# Altium schematic sheet Mach IOT wemos D1.SchDoc
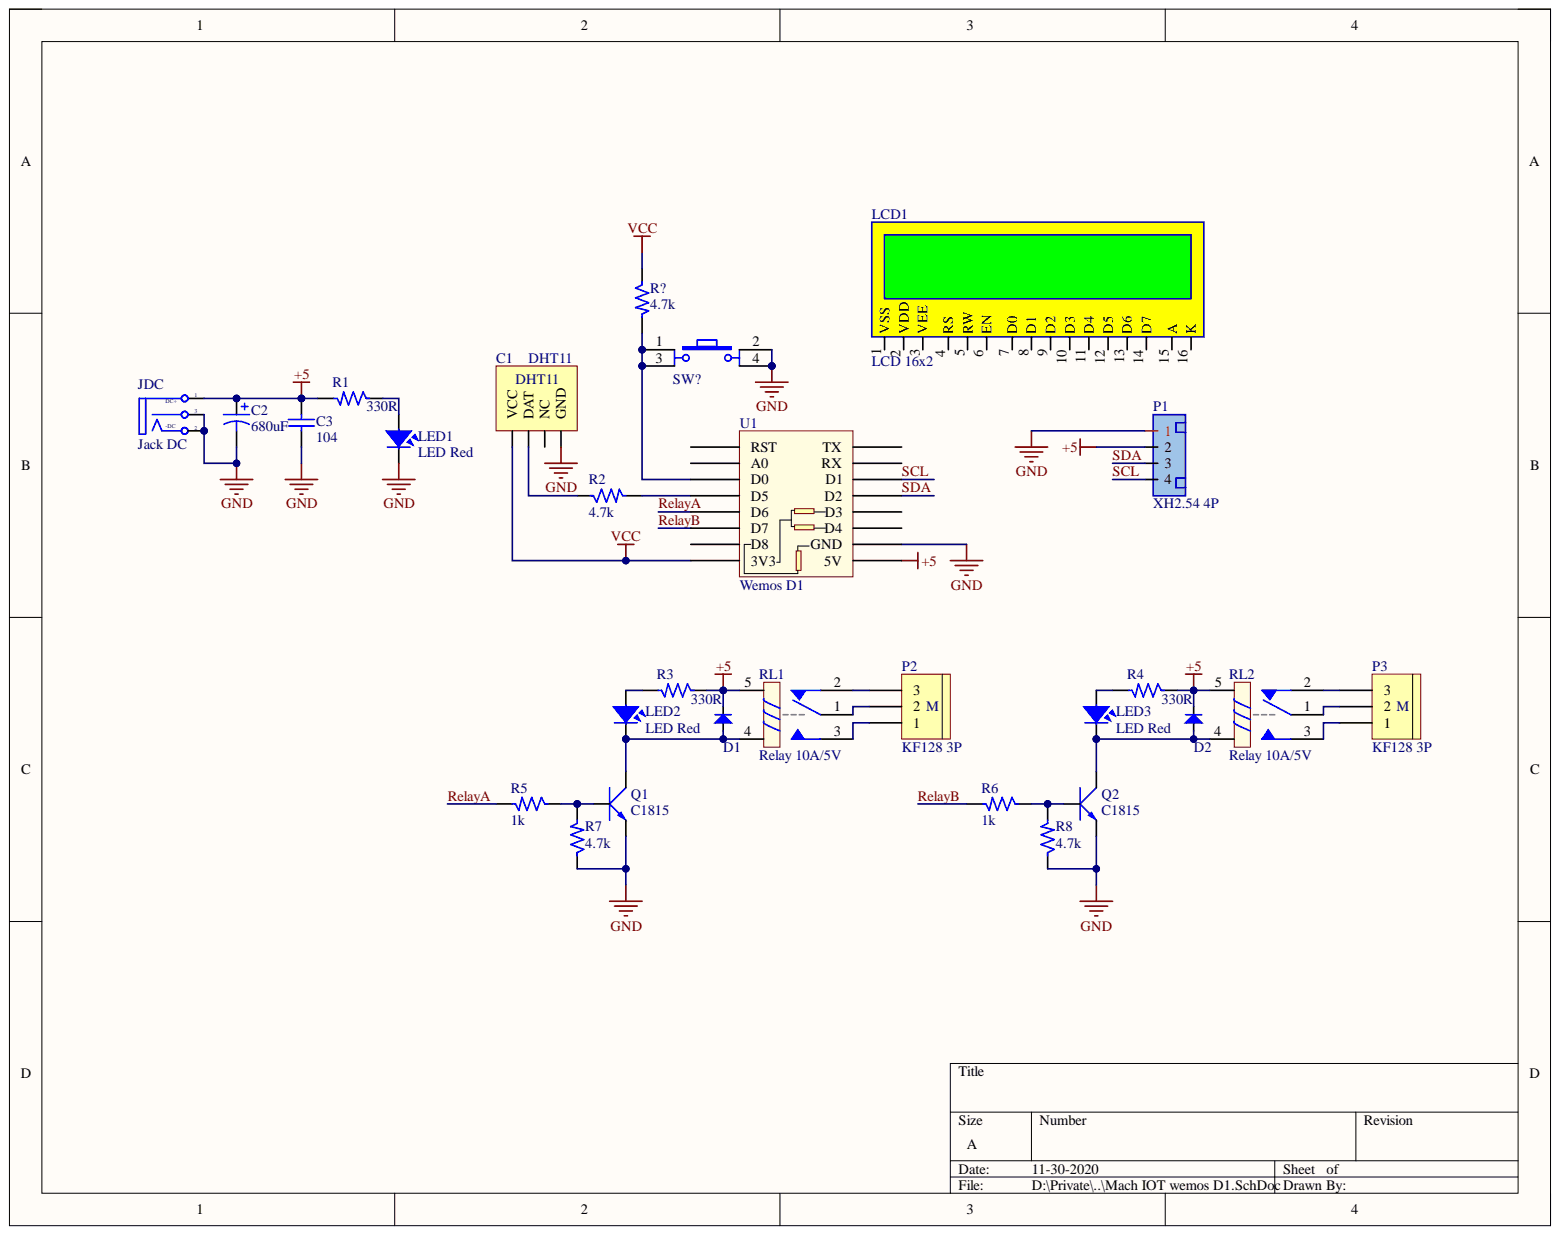
[diagram p0: Mach IOT wemos D1.SchDoc]

## Parts
C1  DHT11  [DHT11]
C2  680uF  [C10mm]
C3  104  [CAP_CERAMIC d2.54]
D1  1n4007  [DIODE/POW/1A]  (value not drawn)
D2  1n4007  [DIODE/POW/1A]  (value not drawn)
JDC  Jack DC  [JACK_DC 5.5 mm]
LCD1  LCD 16x2  [LCD_16x2]
LED1  LED Red  [LED_RED_5MM]
LED2  LED Red  [LED_RED_5MM]
LED3  LED Red  [LED_RED_5MM]
P1  XH2.54 4P  [HEADER 4P XH2.54]
P2  KF128 3P  [KF128 5.08 3P]
P3  KF128 3P  [KF128 5.08 3P]
Q1  C1815  [QTO-92]
Q2  C1815  [QTO-92]
R1  330R  [RES_1/4 W]
R2  4.7k  [RES_1/4 W]
R3  330R  [RES_1/4 W]
R4  330R  [RES_1/4 W]
R5  1k  [RES_1/4 W]
R6  1k  [RES_1/4 W]
R7  4.7k  [RES_1/4 W]
R8  4.7k  [RES_1/4 W]
R?  4.7k  [RES_1/4 W]
RL1  Relay 10A/5V  [Relay 5 pin 10A]
RL2  Relay 10A/5V  [Relay 5 pin 10A]
SW?  BT4  [SW4]  (value not drawn)
U1  Wemos D1  [Wemos D1 mini HEADER]

## Nets
+5: C2.1 C3.2 D1.2 D2.2 JDC.1 P1.2 R1.2 R3.1 R4.1 RL1.5 RL2.5 U1.5V
GND: C1.4(GND) C2.2 C3.1 JDC.2 JDC.3 LED1.2 P1.1 Q1.1 Q2.1 R7.1 R8.1 SW?.2 SW?.4 U1.GND
VCC: C1.1(VCC) R?.1 U1.3V3
RelayA: R5.2 U1.D6
RelayB: R6.2 U1.D7
SCL: P1.4 U1.D1
SDA: P1.3 U1.D2
NetC1_2: C1.2(DAT) R2.1
NetD1_1: D1.1 LED2.2 Q1.2 RL1.4
NetD2_1: D2.1 LED3.2 Q2.2 RL2.4
NetLED1_1: LED1.1 R1.1
NetLED2_1: LED2.1 R3.2
NetLED3_1: LED3.1 R4.2
NetP2_1: P2.1 RL1.3
NetP2_2: P2.2 RL1.1
NetP2_3: P2.3 RL1.2
NetP3_1: P3.1 RL2.3
NetP3_2: P3.2 RL2.1
NetP3_3: P3.3 RL2.2
NetQ1_3: Q1.3 R5.1 R7.2
NetQ2_3: Q2.3 R6.1 R8.2
NetR2_2: R2.2 U1.D5
NetR?_2: R?.2 SW?.1 SW?.3 U1.D0

Not connected: C1 3(NC); LCD1 1(VSS) 2(VDD) 3(VEE) 4(RS) 5(RW) 6(EN) 7(D0) 8(D1) 9(D2) 10(D3) 11(D4) 12(D5) 13(D6) 14(D7) 15(A) 16(K); U1 A0 D3 D4 D8 RST RX TX

## Library data of the parts
C1 = DHT11: Code: 6102-014; ComponentLink1 Description: Manufacturer; ComponentLink2 Description: Datasheet; Component Kind: Standard; ComponentLink1URL: http://www.we-online.com; ComponentLink2URL: http://katalog.we-online.de/em/datasheet/6130xx143121.pdf; Description: DHT11; Library Name: RD Library.SchLib
C2 = Cap Pol 680uF: Polarized Capacitor (Axial) 680uF 35V; Code: 1402-232; Component Kind: Standard; Library Name: RD Library.SchLib
C3 = 104 CAP CERAMIC: Cap CAP CERAMIC 104 - 100nF; Code: 1416-029; Component Kind: Standard; Library Name: RD Library.SchLib
D1 D2 = 1n4007 DIP: Rectifier diode 1n4007; Code: 2001-015; Component Kind: Standard; Library Name: RD Library.SchLib
JDC = Jack DC: Jack DC input. (Nho them adapter); Code: 3546-007; Component Kind: Standard; Library Name: RD Library.SchLib
LCD1 = LCD16x2: Code: 8300-012  8300-009; Component Kind: Standard; Library Name: RD Library.SchLib
LED1-LED3 = LED 5mm RED: LED Single colour Red; Code: 8001-013; Component Kind: Standard; Library Name: RD Library.SchLib
P1 = HEADER 4 Pin XH2.54: XH-T-4 HEADER 4 Pin XH2.54; Code: 3509-003; Component Kind: Standard; Library Name: RD Library.SchLib
P2 P3 = KF128-5.08-3P: Header, 3-Pin, Medium; Code: 3520-014; Component Kind: Standard; Library Name: RD Library.SchLib
Q1 Q2 = C1815 TO-92: NPN 150mA, ECB TO-02; Code: 1701-053; Component Kind: Standard; Library Name: RD Library.SchLib
R1-R8 R? = Res 1/4W: Component Kind: Standard; Description: Res 1/4W; Library Name: RD Library.SchLib
RL1 RL2 = Relay 5V 5 Pin 10A SLC: Relay SPDT,10A - 5VDC 5 Pin SONGLE SLC; Code: 2401-001; Component Kind: Standard; Library Name: RD Library.SchLib
SW? = SW4: Switch button 4 pin; Code: 3605-001; Component Kind: Standard; Library Name: RD Library.SchLib
U1 = Wemos D1: Node MCU D1 Mini; Component Kind: Standard; Library Name: RD Library.SchLib
